# Altium schematic sheet Adapter board.SchDoc
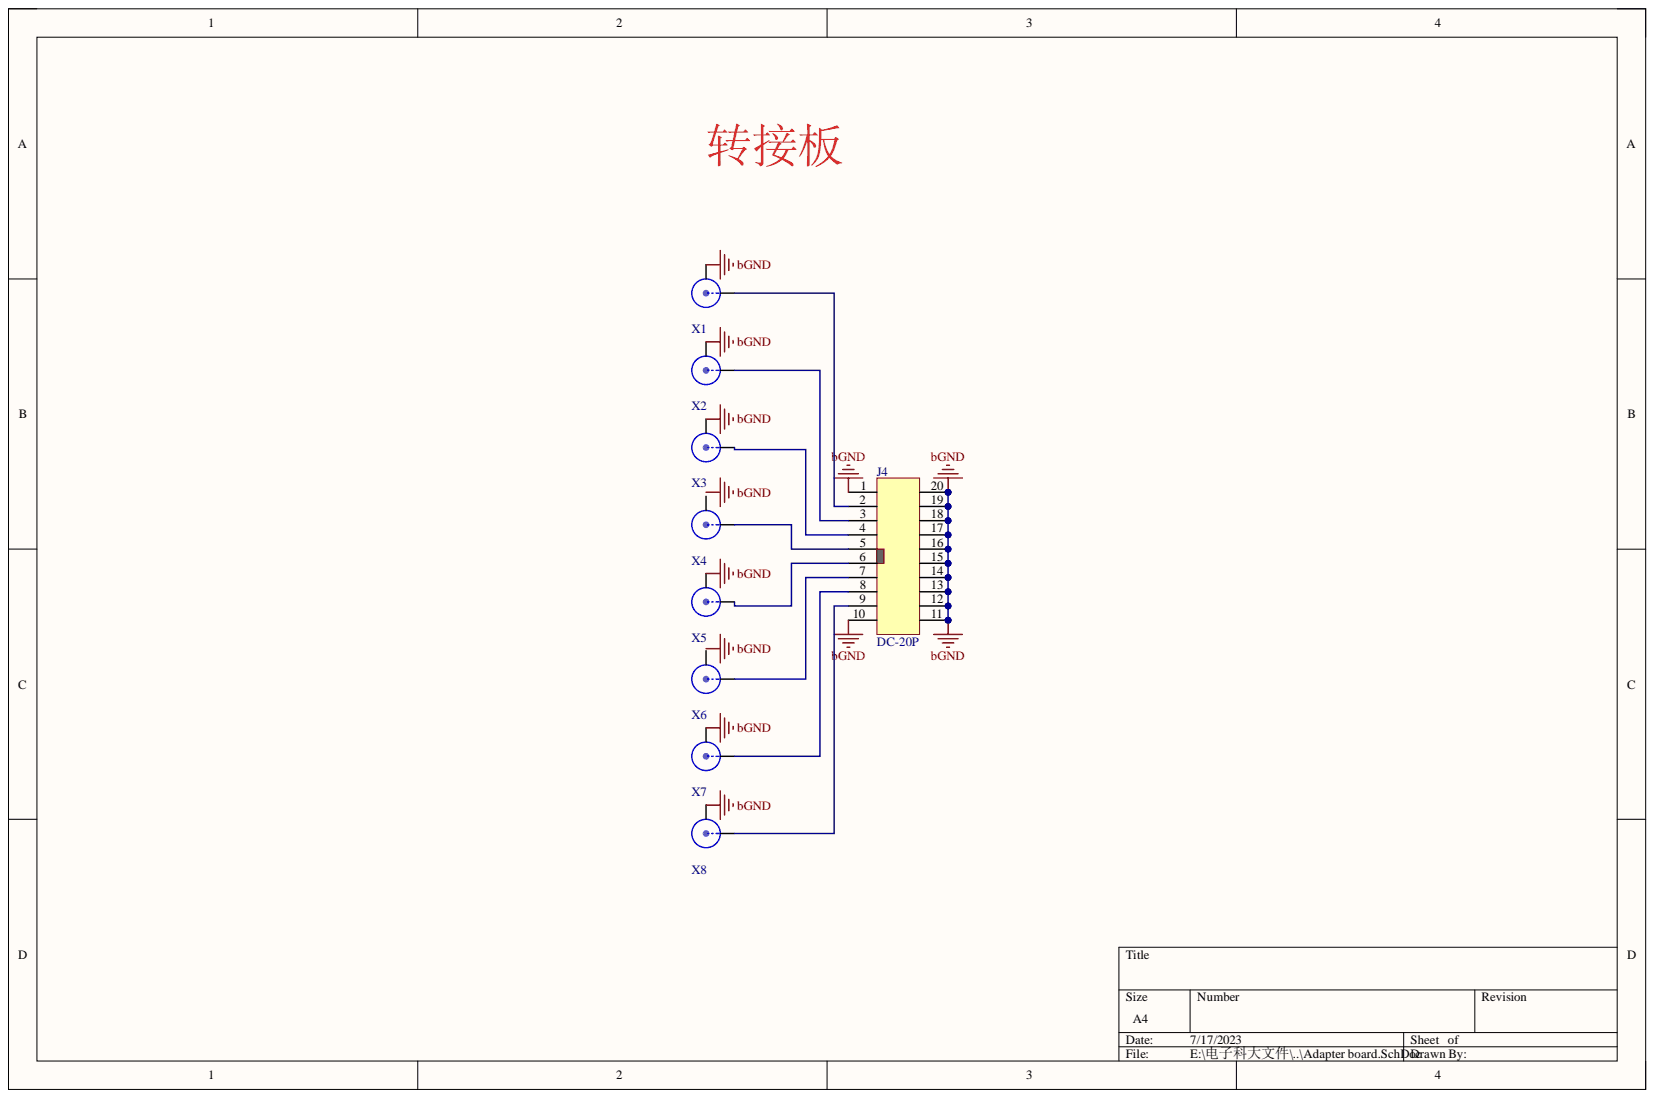
[diagram p0: Adapter board.SchDoc]

## Parts
J4  DC-20P  [DC-20P]
X1  SMA  [SMA_ANGLE]  (value not drawn)
X2  SMA  [SMA_ANGLE]  (value not drawn)
X3  SMA  [SMA_ANGLE]  (value not drawn)
X4  SMA  [SMA_ANGLE]  (value not drawn)
X5  SMA  [SMA_ANGLE]  (value not drawn)
X6  SMA  [SMA_ANGLE]  (value not drawn)
X7  SMA  [SMA_ANGLE]  (value not drawn)
X8  SMA  [SMA_ANGLE]  (value not drawn)

## Nets
bGND: J4.1 J4.10 J4.11 J4.12 J4.13 J4.14 J4.15 J4.16 J4.17 J4.18 J4.19 J4.20 X1.2 X2.2 X3.2 X5.2 X7.2 X8.2
NetJ4_2: J4.2 X1.1
NetJ4_3: J4.3 X2.1
NetJ4_4: J4.4 X3.1
NetJ4_5: J4.5 X4.1
NetJ4_6: J4.6 X5.1
NetJ4_7: J4.7 X6.1
NetJ4_8: J4.8 X7.1
NetJ4_9: J4.9 X8.1

Not connected: X4 2; X6 2

## Library data of the parts
X1-X8 = SMA_1: Component Kind: Standard; Library Name: HZZ.IntLib
J4 = DC-20P: Component Kind: Standard; Library Name: HZZ.IntLib
